# Altium schematic sheet HWSD-DBUS.SchDoc
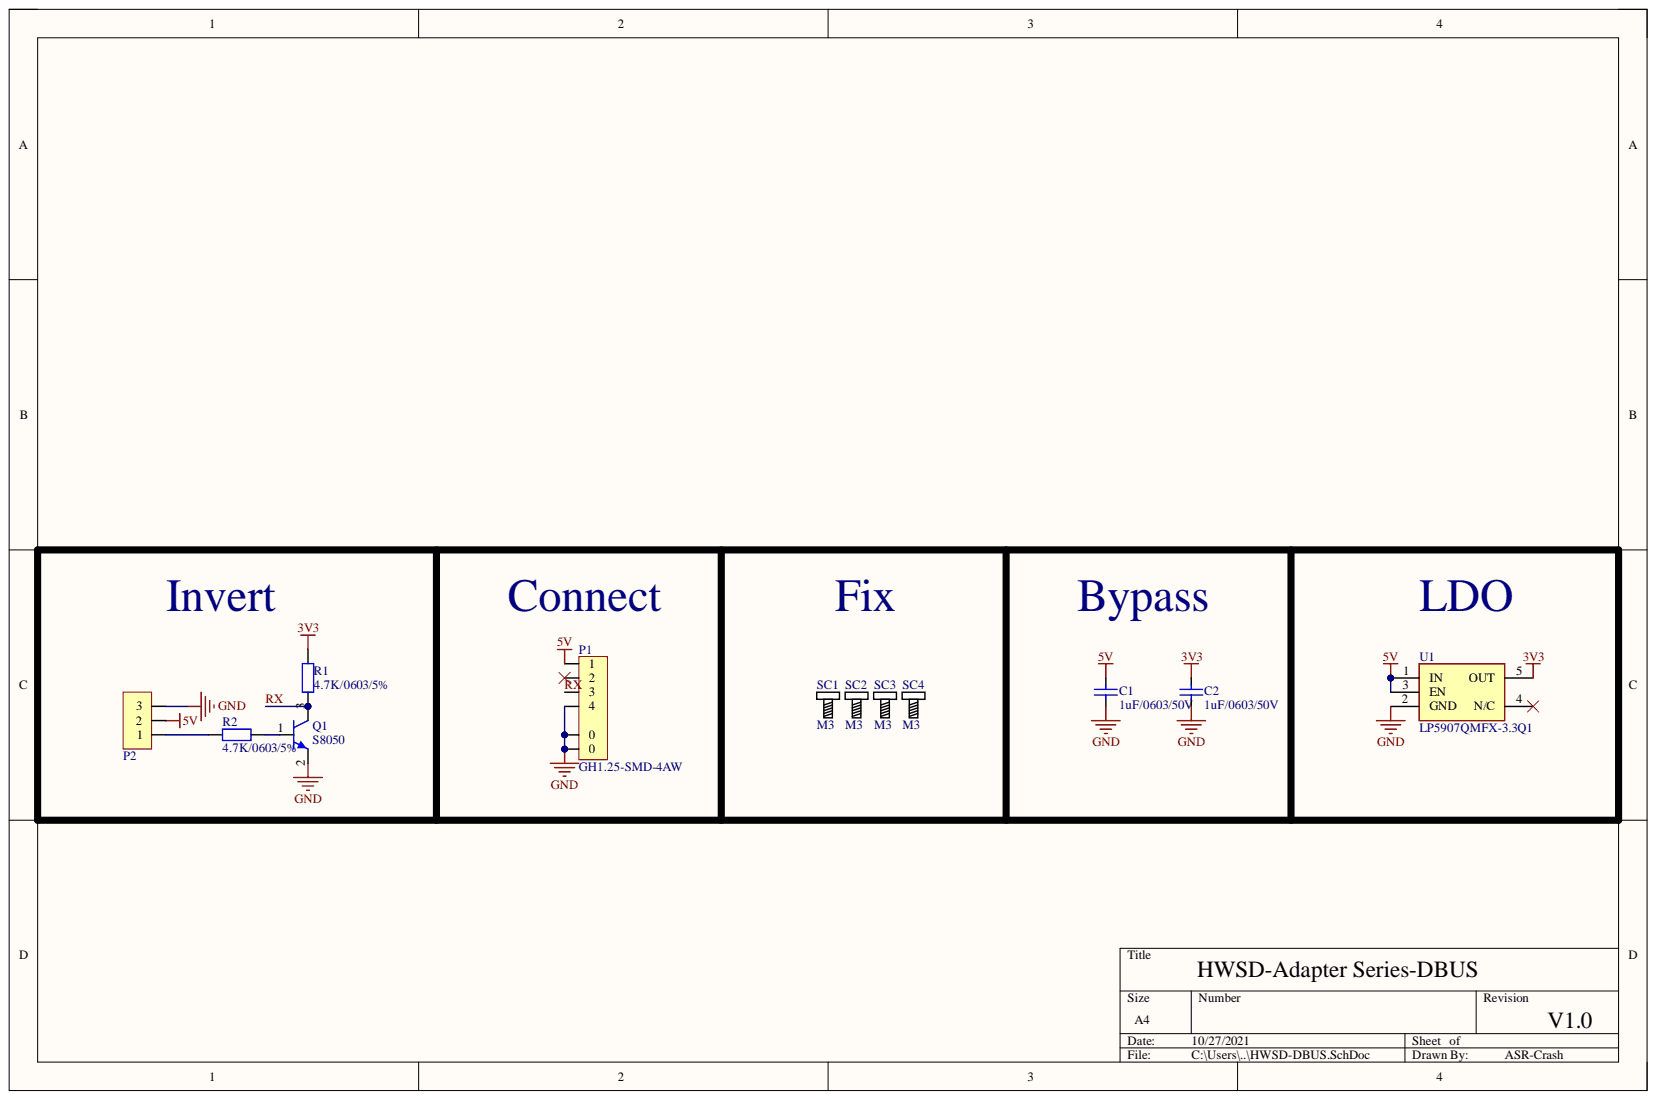
[diagram p0: HWSD-DBUS.SchDoc]

## Parts
C1  value 1uF/0603/50V  [C-SMD-0603]  (hidden comment: Cap_0603)
C2  value 1uF/0603/50V  [C-SMD-0603]  (hidden comment: Cap_0603)
P1  GH1.25-SMD-4AW  [GH1.25_4 贴卧]
P2  Header 3  [HDR1*3 - Horizon - 1]  (value not drawn)
Q1  S8050  [SOT23-3]
R1  value 4.7K/0603/5%  [R-SMD-0603]  (hidden comment: Res_0603)
R2  value 4.7K/0603/5%  [R-SMD-0603]  (hidden comment: Res_0603)
SC1  M3  [SCRREW_M3]
SC2  M3  [SCRREW_M3]
SC3  M3  [SCRREW_M3]
SC4  M3  [SCRREW_M3]
U1  LP5907QMFX-3.3Q1  [SOT23-5]

## Nets
3V3: C2.2 R1.1 U1.5(OUT)
5V: C1.2 P1.1 P2.2 U1.1(IN) U1.3(EN)
GND: C1.1 C2.1 P1.0 P1.4 P2.3 Q1.2 U1.2(GND)
RX: P1.3 Q1.3 R1.2
NetP2_1: P2.1 R2.2
NetQ1_1: Q1.1 R2.1

Not connected: P1 2; U1 4(N/C)

## Library data of the parts
C1 C2 = Cap_0603: Capacitor; Component Kind: Standard; Library Name: Hardware Standardization Library.SchLib
P1 = GH1.25-SMD-4AW: Interface; Component Kind: Standard; Library Name: Hardware Standardization Library.SchLib
P2 = Header 3_Horizon_1: Header; Component Kind: Standard; LatestRevisionDate: 17-Jul-2002; LatestRevisionNote: Re-released for DXP Platform.; Library Name: Hardware Standardization Library.SchLib; Publisher: Altium Limited
Q1 = S8050_2: NPN三极管贴片; Component Kind: Standard; Library Name: 二极管三级管晶振蜂鸣器.SchLib
R1 R2 = Res_0603: Resistor; Component Kind: Standard; Library Name: Hardware Standardization Library.SchLib
SC1-SC4 = Screw_M3: Screw; Component Kind: Standard; Library Name: Hardware Standardization Library.SchLib
U1 = LP5907QMFX-3.3Q1: LDO; Manufacturer_Name: Texas Instruments; Component Kind: Standard; Datasheet Link: http://www.ti.com/lit/gpn/lp5907-q1; Height: 1.45mm; Manufacturer_Part_Number: LP5907QMFX-3.3Q1; Mouser Part Number: 595-LP5907QMFX-3.3Q1; Mouser Price/Stock: https://www.mouser.com/Search/Refine.aspx?Keyword=595-LP5907QMFX-3.3Q1; Library Name: Hardware Standardization Library.SchLib
